# Altium schematic sheet Interfaces.SchDoc
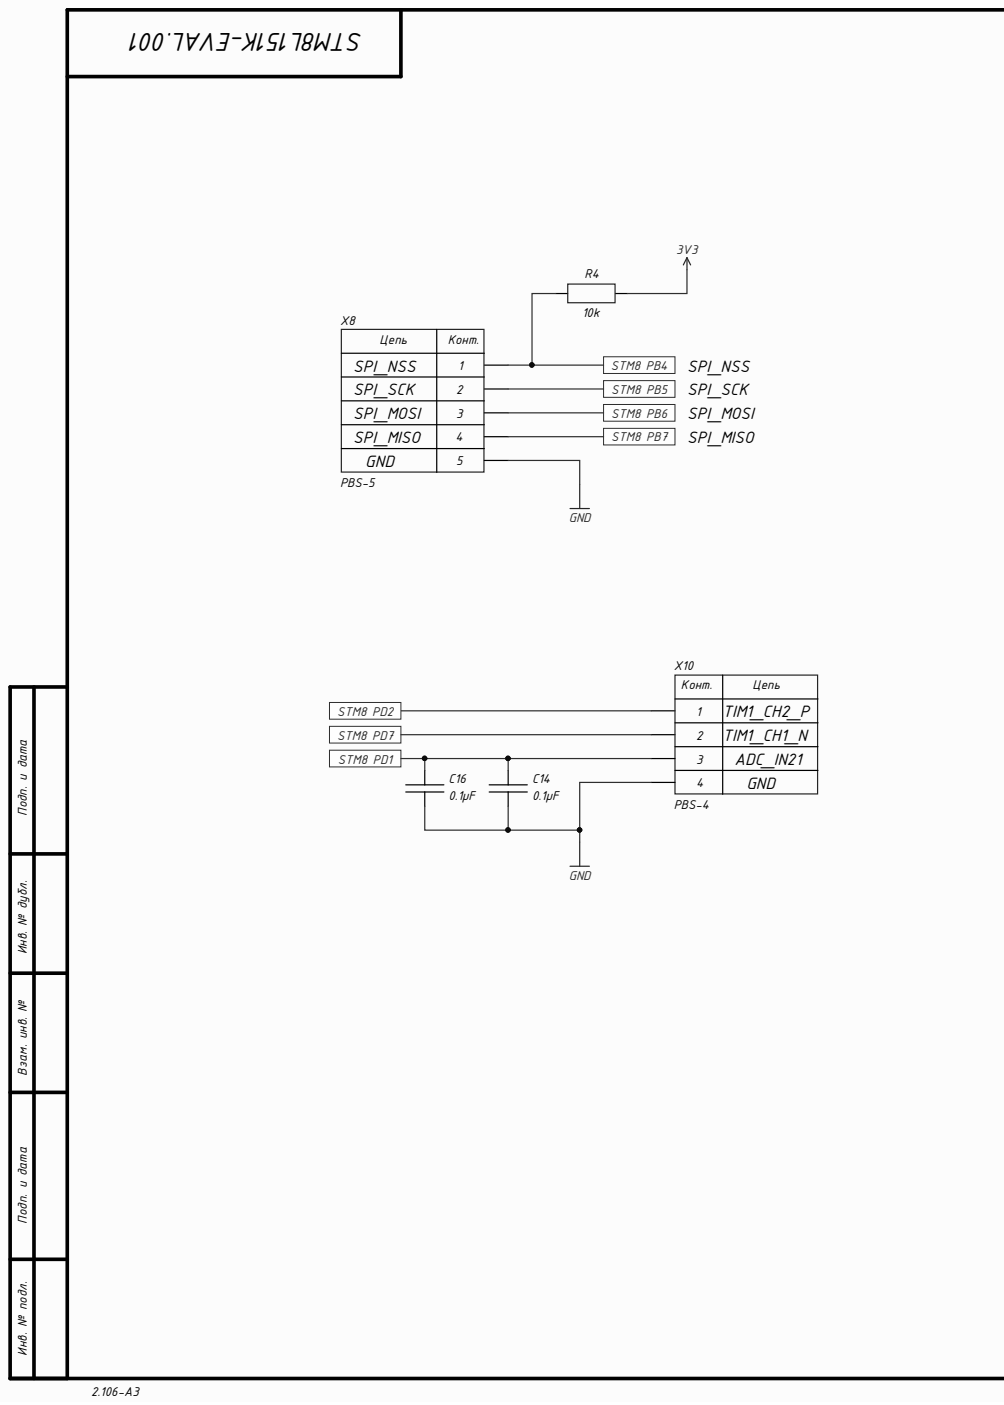
[diagram p0: Interfaces.SchDoc, left]
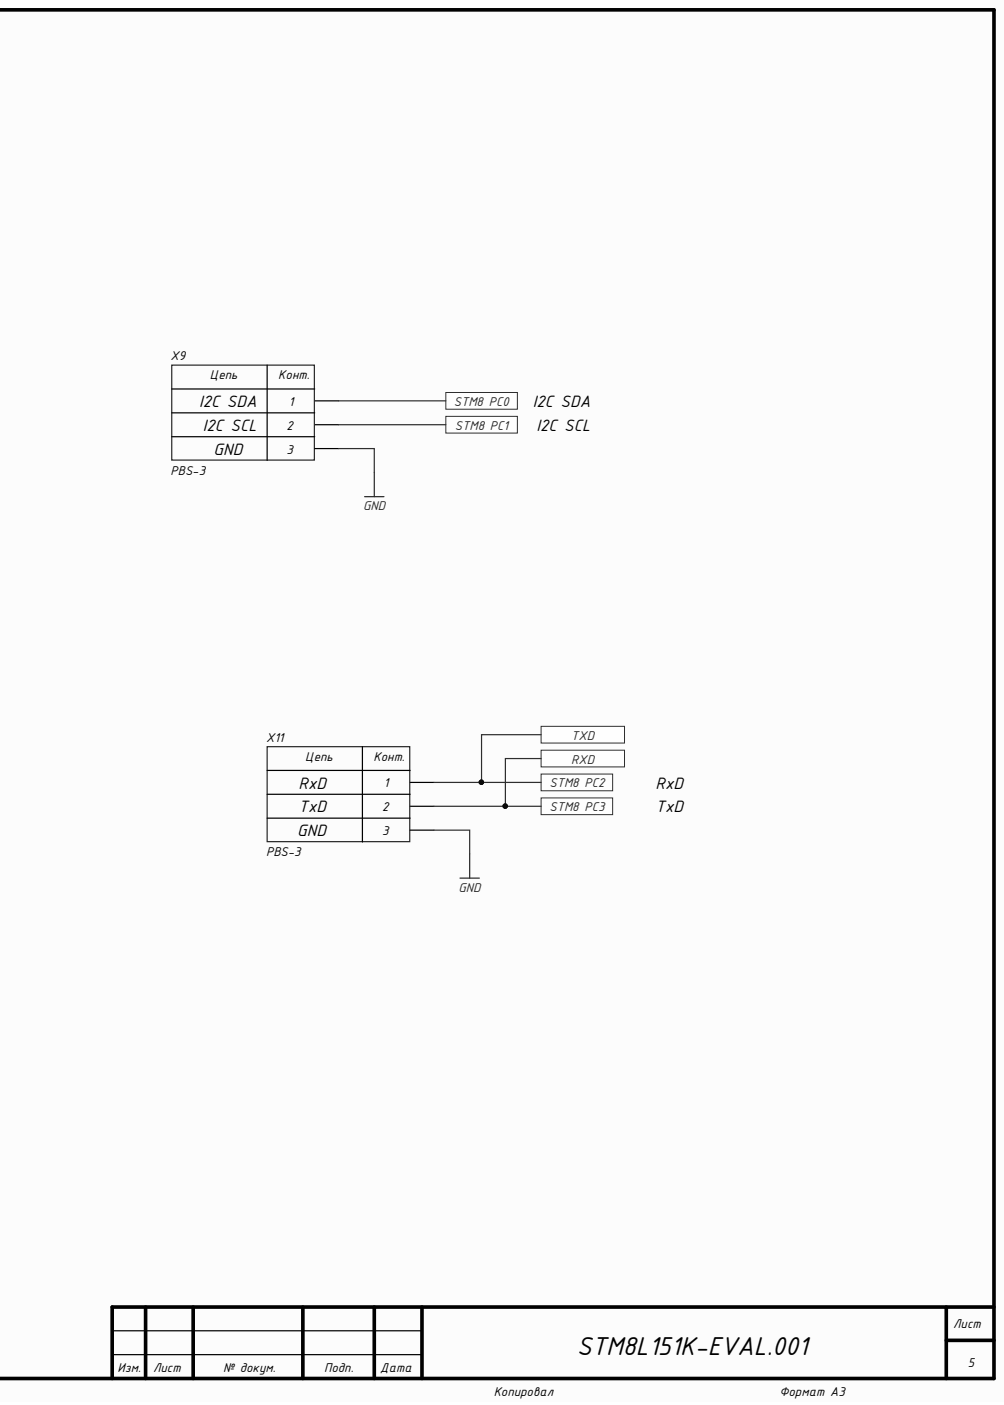
[diagram p1: Interfaces.SchDoc, right]

## Parts
C14  0.1µF  [CH0603C]
C16  0.1µF  [CH0603C]
R4  10k  [CH0603R]
X8  PBS-5  [CON: PBS-5]
X9  PBS-3  [CON: PBS-3]
X10  PBS-4  [CON: PBS-4]
X11  PBS-3  [CON: PBS-3]

## Ports
RXD, STM8 PB4, STM8 PB5, STM8 PB6, STM8 PB7, STM8 PC0, STM8 PC1, STM8 PC2, STM8 PC3, STM8 PD1, STM8 PD2, STM8 PD7, TXD

## Nets
3V3: R4.2
GND: C14.1 C16.1 X8.5 X9.3 X10.4 X11.3
RXD: X11.2 ; port RXD, port STM8 PC3
STM8 PB4: R4.1 X8.1 ; port STM8 PB4
STM8 PB5: X8.2 ; port STM8 PB5
STM8 PB6: X8.3 ; port STM8 PB6
STM8 PB7: X8.4 ; port STM8 PB7
STM8 PC0: X9.1 ; port STM8 PC0
STM8 PC1: X9.2 ; port STM8 PC1
STM8 PC2: X11.1 ; port STM8 PC2, port TXD
STM8 PD1: C14.2 C16.2 X10.3 ; port STM8 PD1
STM8 PD2: X10.1 ; port STM8 PD2
STM8 PD7: X10.2 ; port STM8 PD7

## Library data of the parts
C14 C16 = Capacitor: Component Kind: Standard; Library Name: Discrete.IntLib; Value: 0.1µF
R4 = Resistor: Component Kind: Standard; Library Name: Discrete.IntLib; Value: 10k
X8 = 5-Connector: Component Kind: Standard; Library Name: Connectors.IntLib; Model: PBS-5
X9 X11 = 3-Connector: Component Kind: Standard; Library Name: Connectors.IntLib; Model: PBS-3
X10 = 4-Connector: Component Kind: Standard; Library Name: Connectors.IntLib; Model: PBS-4
